# Altium schematic sheet Regulation_PID.SchDoc
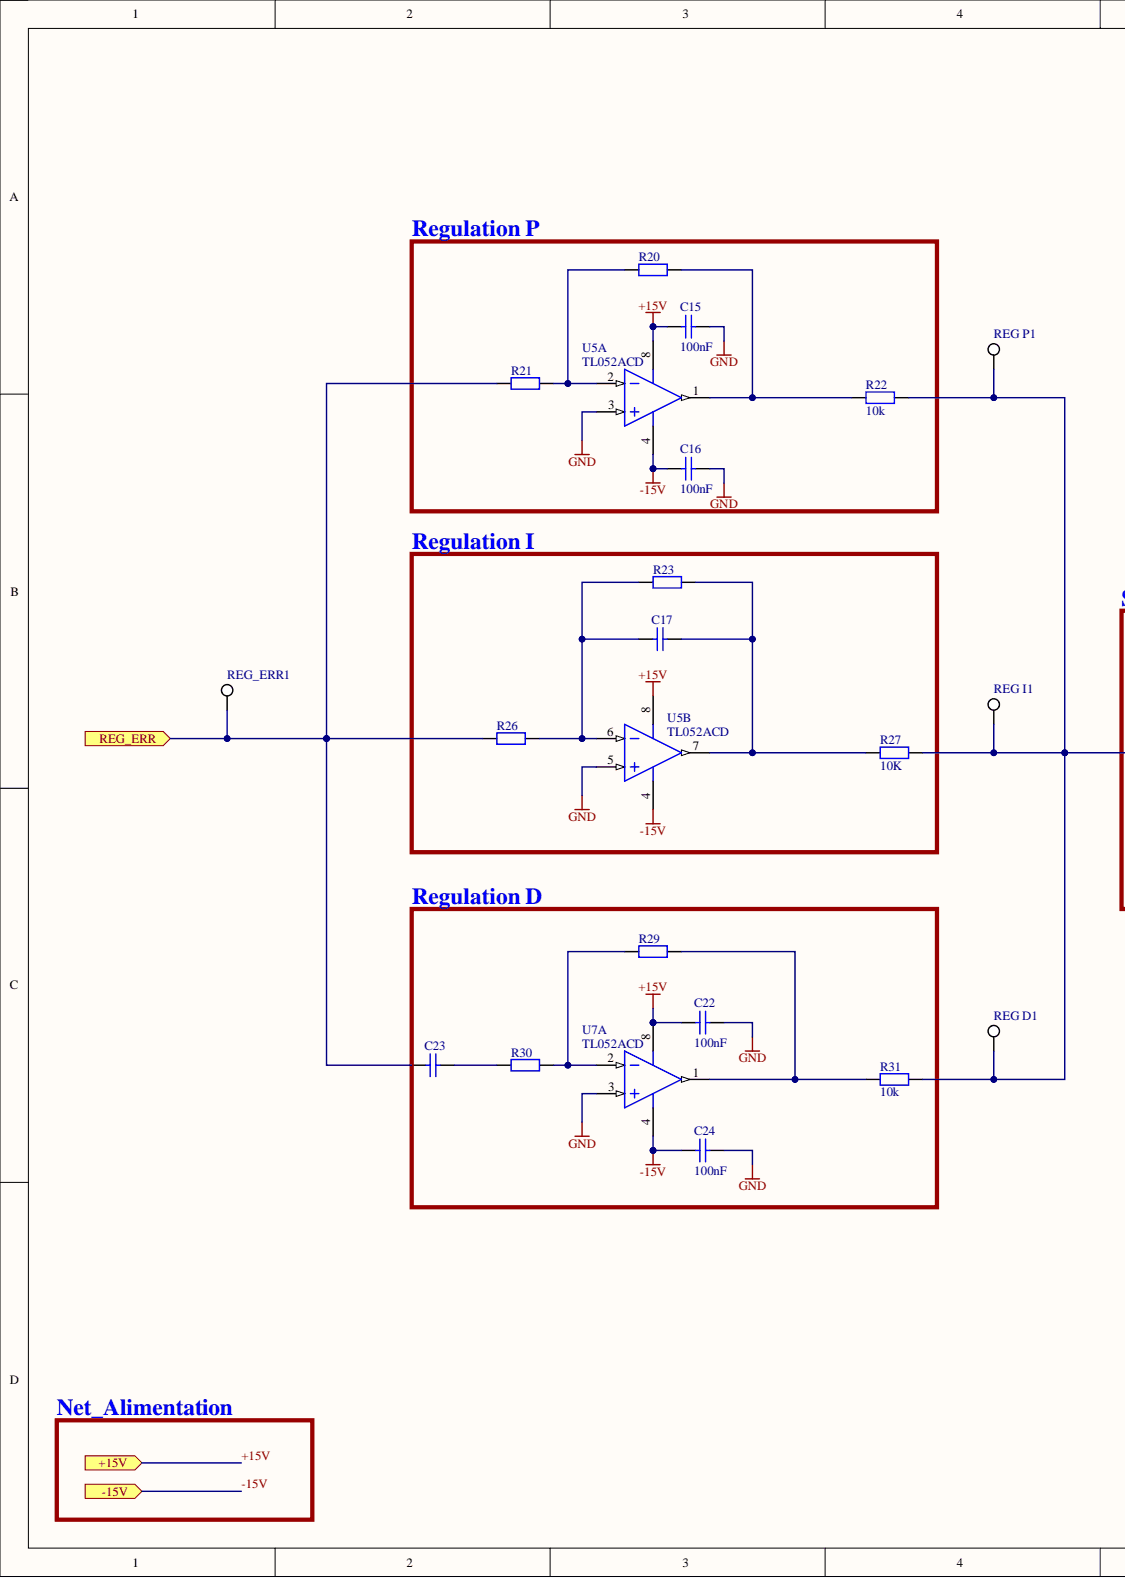
[diagram p0: Regulation_PID.SchDoc, left]
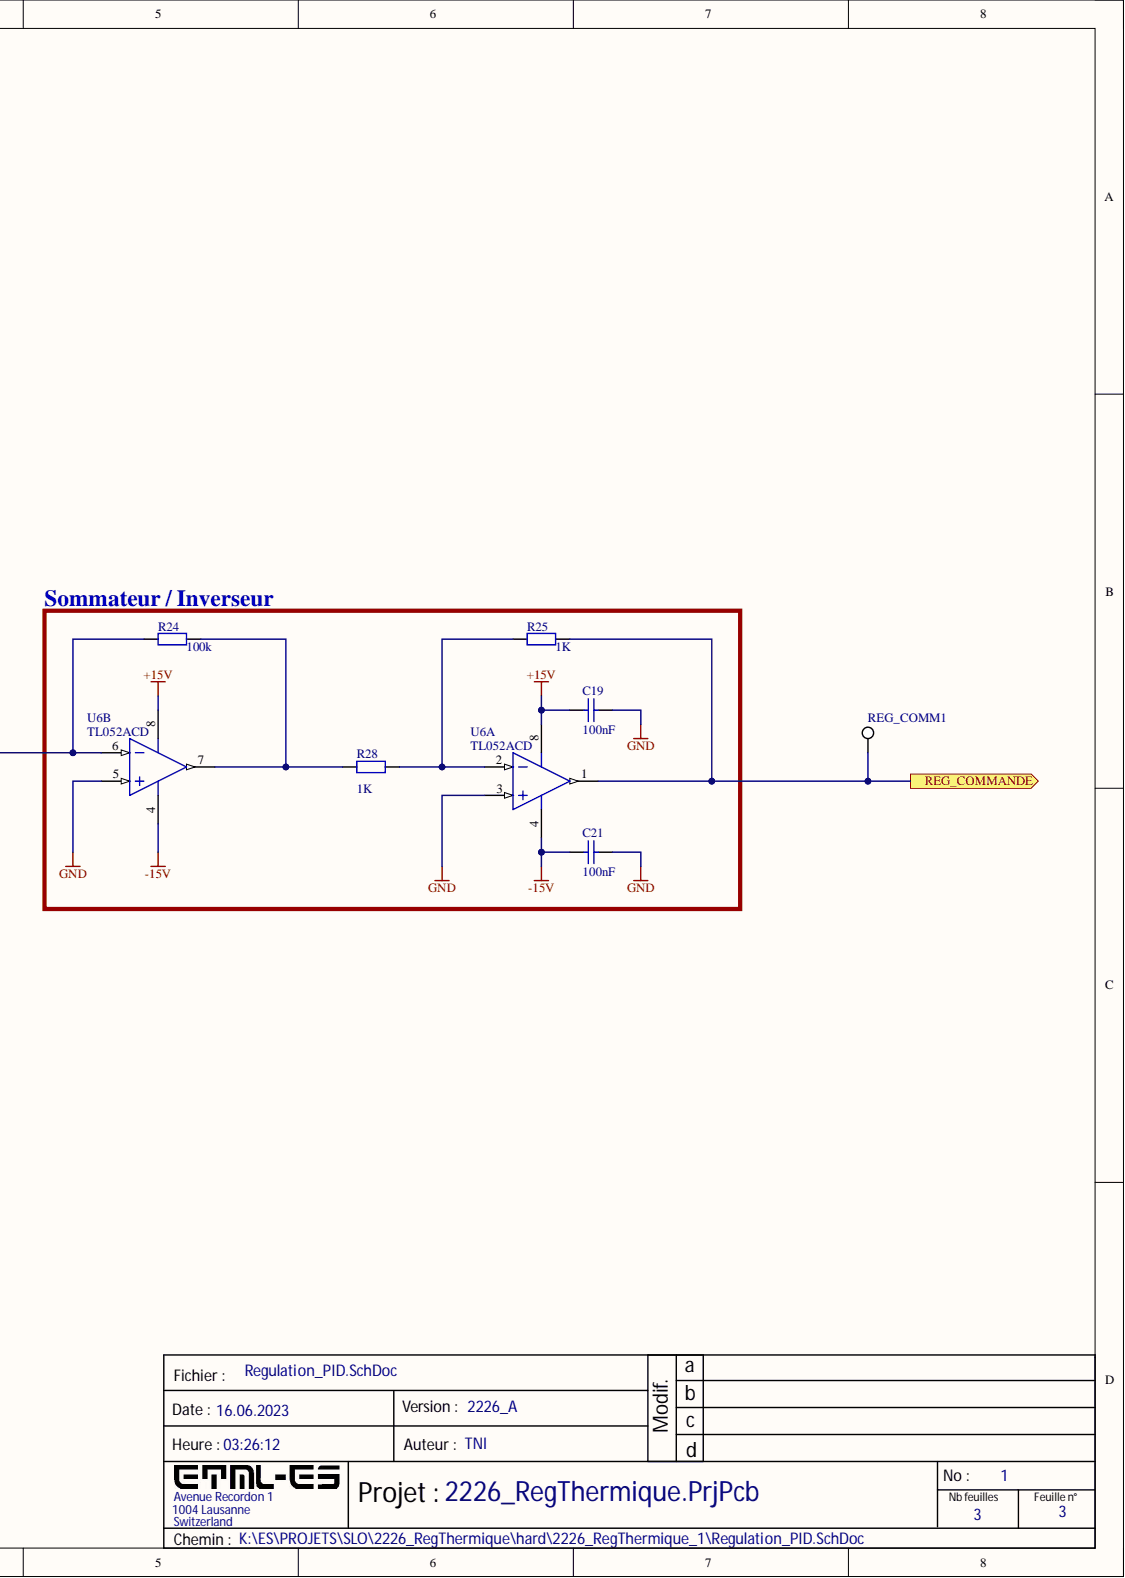
[diagram p1: Regulation_PID.SchDoc, right]

## Parts
C15  value 100nF  [C0805]  (hidden comment: Cap)
C16  value 100nF  [C0805]  (hidden comment: Cap)
C17  Cap  [AXIAL-0.4]  (value not drawn)
C19  value 100nF  [C0805]  (hidden comment: Cap)
C21  value 100nF  [C0805]  (hidden comment: Cap)
C22  value 100nF  [C0805]  (hidden comment: Cap)
C23  Cap  [AXIAL-0.4]  (value not drawn)
C24  value 100nF  [C0805]  (hidden comment: Cap)
R20  Res2  [AXIAL-0.4]  (value not drawn)
R21  Res2  [AXIAL-0.4]  (value not drawn)
R22  10k  [AXIAL-0.4]
R23  Res2  [AXIAL-0.4]  (value not drawn)
R24  value 100k  [6-0805_N]  (hidden comment: Res2)
R25  value 1K  [6-0805_N]  (hidden comment: Res2)
R26  Res2  [AXIAL-0.4]  (value not drawn)
R27  10K  [AXIAL-0.4]
R28  value 1K  [6-0805_N]  (hidden comment: Res2)
R29  Res2  [AXIAL-0.4]  (value not drawn)
R30  Res2  [AXIAL-0.4]  (value not drawn)
R31  10k  [AXIAL-0.4]
REG_D1  REGP  [KSTN-5019_V]  (value not drawn)
REG_I1  REGP  [KSTN-5019_V]  (value not drawn)
REG_P1  REGP  [KSTN-5019_V]
REG_COMM1  REGP  [KSTN-5019_V]  (value not drawn)
REG_ERR1  REGP  [KSTN-5019_V]  (value not drawn)
U5  TL052ACD  [D0008A_N]  (parts A,B)
U6  TL052ACD  [D0008A_N]  (parts A,B)
U7  TL052ACD  [D0008A_N]  (part A)

## Ports
+15V, -15V, REG_COMMANDE, REG_ERR

## Nets
GND: C15.1 C16.1 C19.1 C21.1 C22.1 C24.1 U5.3 U5.5 U6.3 U6.5 U7.3
+15V: C15.2 C19.2 C22.2 U5.8 U6.8 U7.8 ; port +15V
-15V: C16.2 C21.2 C24.2 U5.4 U6.4 U7.4 ; port -15V
REG_COMMANDE: R25.2 REG_COMM1.1 U6.1 ; port REG_COMMANDE
REG_ERR: C23.2 R21.1 R26.1 REG_ERR1.1 ; port REG_ERR
NetC17_1: C17.1 R23.2 R27.1 U5.7
NetC17_2: C17.2 R23.1 R26.2 U5.6
NetC23_1: C23.1 R30.1
NetR20_1: R20.1 R21.2 U5.2
NetR20_2: R20.2 R22.1 U5.1
NetR22_2: R22.2 R24.1 R27.2 R31.2 REG_D1.1 REG_I1.1 REG_P1.1 U6.6
NetR24_2: R24.2 R28.1 U6.7
NetR25_1: R25.1 R28.2 U6.2
NetR29_1: R29.1 R30.2 U7.2
NetR29_2: R29.2 R31.1 U7.1

## Library data of the parts
C15 C16 C19 C21 C22 C24 = Cap: Cap 100nF; Component Kind: Standard; LatestRevisionDate: 17-Jul-2002; LatestRevisionNote: Re-released for DXP Platform.; Library Name: Miscellaneous Devices.IntLib; PackageDescription: Radial Cap, Thru-Hole; 2 Leads; 0.3 in Pin Spacing; PackageReference: RAD-0.3; Published: 8-Jun-2000; Signal Integrity: Cap; Simulation: CAP; Publisher: Altium Limited
  C15: Value: 100nF
C17 C23 = Cap: Capacitor; Value: 100pF; Component Kind: Standard; LatestRevisionDate: 17-Jul-2002; LatestRevisionNote: Re-released for DXP Platform.; Library Name: Miscellaneous Devices.IntLib; PackageDescription: Radial Cap, Thru-Hole; 2 Leads; 0.3 in Pin Spacing; PackageReference: RAD-0.3; Published: 8-Jun-2000; Signal Integrity: Cap; Simulation: CAP; Publisher: Altium Limited
R20 R21 = Res2: Resistor; Component Kind: Standard; LatestRevisionDate: 17-Jul-2002; LatestRevisionNote: Re-released for DXP Platform.; Library Name: Miscellaneous Devices.IntLib; PackageDescription: Axial Device, Thru-Hole; 2 Leads; 0.4 in Pin Spacing; PackageReference: AXIAL-0.4; Published: 8-Jun-2000; Signal Integrity: Res; Simulation: RESISTOR; Value: -; Publisher: Altium Limited
R22 R27 R31 = Res2: Res 100k; Value: 1K; Component Kind: Standard; LatestRevisionDate: 17-Jul-2002; LatestRevisionNote: Re-released for DXP Platform.; Library Name: Miscellaneous Devices.IntLib; PackageDescription: Axial Device, Thru-Hole; 2 Leads; 0.4 in Pin Spacing; PackageReference: AXIAL-0.4; Published: 8-Jun-2000; Signal Integrity: Res; Simulation: RESISTOR; Publisher: Altium Limited
R23 R26 R29 R30 = Res2: Resistor; Value: 1K; Component Kind: Standard; LatestRevisionDate: 17-Jul-2002; LatestRevisionNote: Re-released for DXP Platform.; Library Name: Miscellaneous Devices.IntLib; PackageDescription: Axial Device, Thru-Hole; 2 Leads; 0.4 in Pin Spacing; PackageReference: AXIAL-0.4; Published: 8-Jun-2000; Signal Integrity: Res; Simulation: RESISTOR; Publisher: Altium Limited
R24 = Res2: Res 100k; Component Kind: Standard; LatestRevisionDate: 17-Jul-2002; LatestRevisionNote: Re-released for DXP Platform.; Library Name: Miscellaneous Devices.IntLib; PackageDescription: Axial Device, Thru-Hole; 2 Leads; 0.4 in Pin Spacing; PackageReference: AXIAL-0.4; Published: 8-Jun-2000; Signal Integrity: Res; Simulation: RESISTOR; Publisher: Altium Limited
R25 R28 = Res2: Res 1k; Component Kind: Standard; LatestRevisionDate: 17-Jul-2002; LatestRevisionNote: Re-released for DXP Platform.; Library Name: Miscellaneous Devices.IntLib; PackageDescription: Axial Device, Thru-Hole; 2 Leads; 0.4 in Pin Spacing; PackageReference: AXIAL-0.4; Published: 8-Jun-2000; Signal Integrity: Res; Simulation: RESISTOR; Publisher: Altium Limited
REG_COMM1 REG_D1 REG_ERR1 REG_I1 REG_P1 = CMP-1672-00008-1: Test Point, 1 Position SMD, RoHS, Tape and Reel; Component Kind: Standard; ComponentLink1Description: Manufacturer URL; ComponentLink1URL: http://www.keyelco.com/; ComponentLink2Description: Datasheet; ComponentLink2URL: http://www.keyelco.com/product-pdf.cfm?p=1357; Contact Plating: Silver; DatasheetVersion: 03/2014; Library Name: Altium Content Vault; Mount: Surface Mount; Mounting Technology: Surface Mount; Package Quantity: 1000; PackageDescription: 1-Pin Surface Mount Device, Body 3.81 x 2.03 mm; PackageReference: 5019; Packaging: Tape and Reel; Pins: 1; RoHSCompliant: True
U5-U7 = CMP-0015-00087-2: Enhanced JFET Precision Dual Operational Amplifier, 10 to 30 V, 0 to 70 degC, 8-pin SOIC (D8), Green (RoHS & no Sb/Br); Manufacturer: Texas Instruments; CMRR(Min)(dB): 75; GBW(Typ)(MHz): 3; IIB(Max)(pA): 200; Iq per channel(Max)(mA): 2.8; Number of Channels: 2; Offset Drift(Typ)(uV/C): 6; Rating: Military; Slew Rate(Typ)(V/us): 20.7; Sub Family: General Purpose Amplifier, High Supply Voltage Amplifier (>=30V); Total Supply Voltage(Max)(+5V is 5, +/-5V is 10): 30; Total Supply Voltage(Min)(+5V is 5, +/-5V is 10): 10; Vio (25C)(Max)(mV): 0.8; Vn at 1kHz(Typ)(nV/rtHz): 19; Code_JEDEC: MS-012; Component Kind: Standard; ComponentLink1Description: Manufacturer URL; ComponentLink1URL: http://www.ti.com/; ComponentLink2Description: Datasheet; ComponentLink2URL: http://www.ti.com/lit/ds/symlink/tl052a.pdf; ComponentLink3Description: Package Specification; ComponentLink3URL: http://www.ti.com/litv/pdf/msoi002j; DatasheetVersion: SLOS178A; Eco-Plan: Green (RoHS & no Sb/Br); Library Name: Altium Content Vault; Mounting Technology: Surface Mount; PackageDescription: 8-Pin Plastic Small Outline, Body 4.9 x 3.9 mm, Pitch 1.27 mm; PackageReference: D0008A; PackageVersion: 4040047-3/M, 06/2011; PartNumber: TL052ACD
